# Altium schematic sheet 005_Power.SchDoc(005_Power)
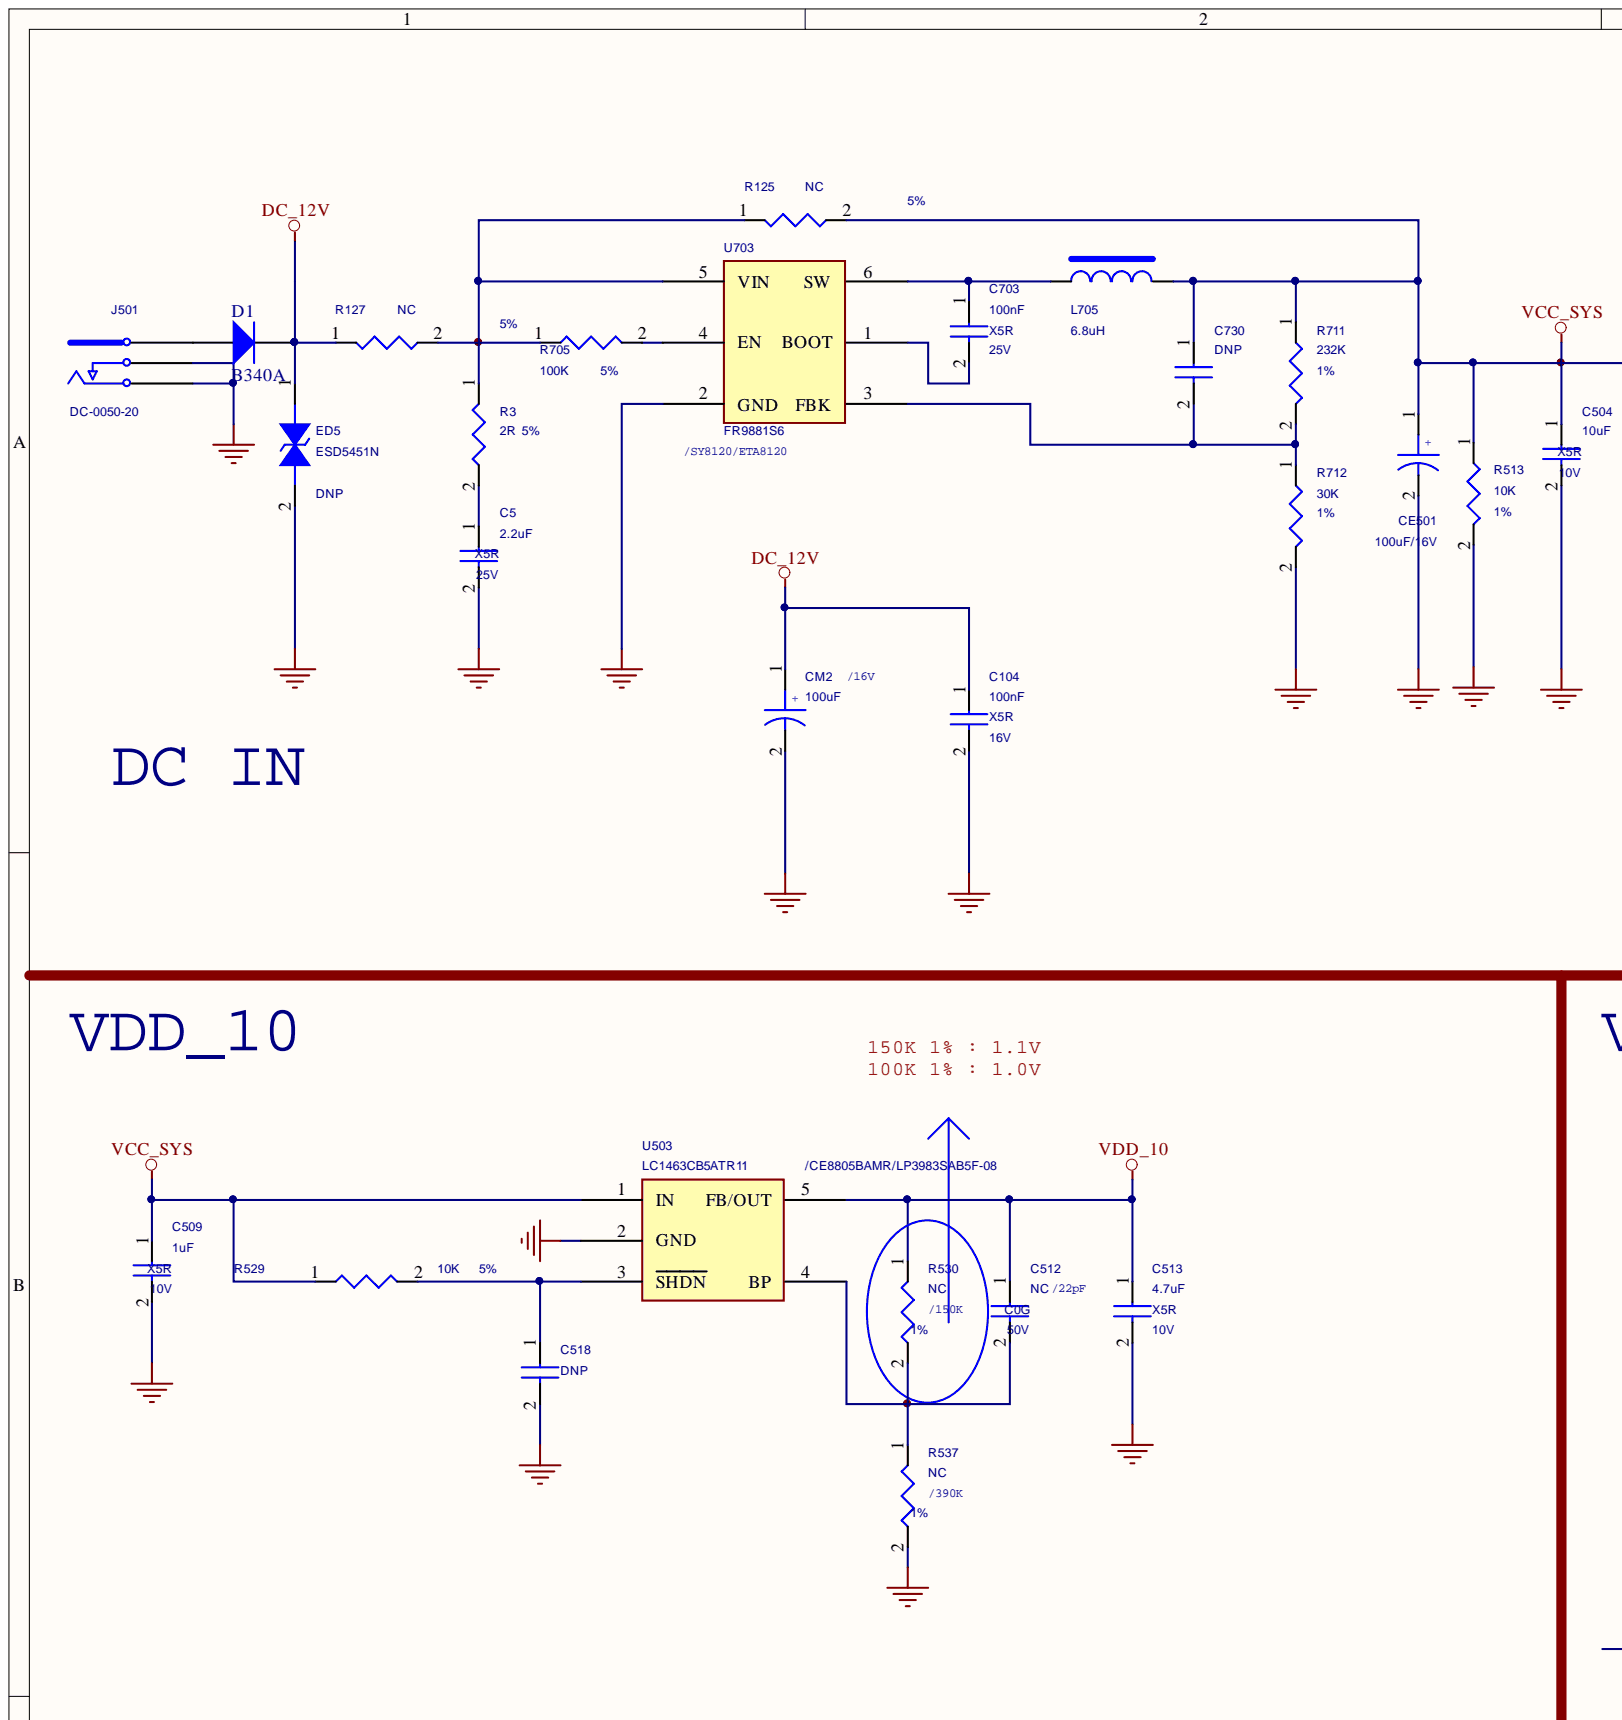
[diagram p0: 005_Power.SchDoc, top left]
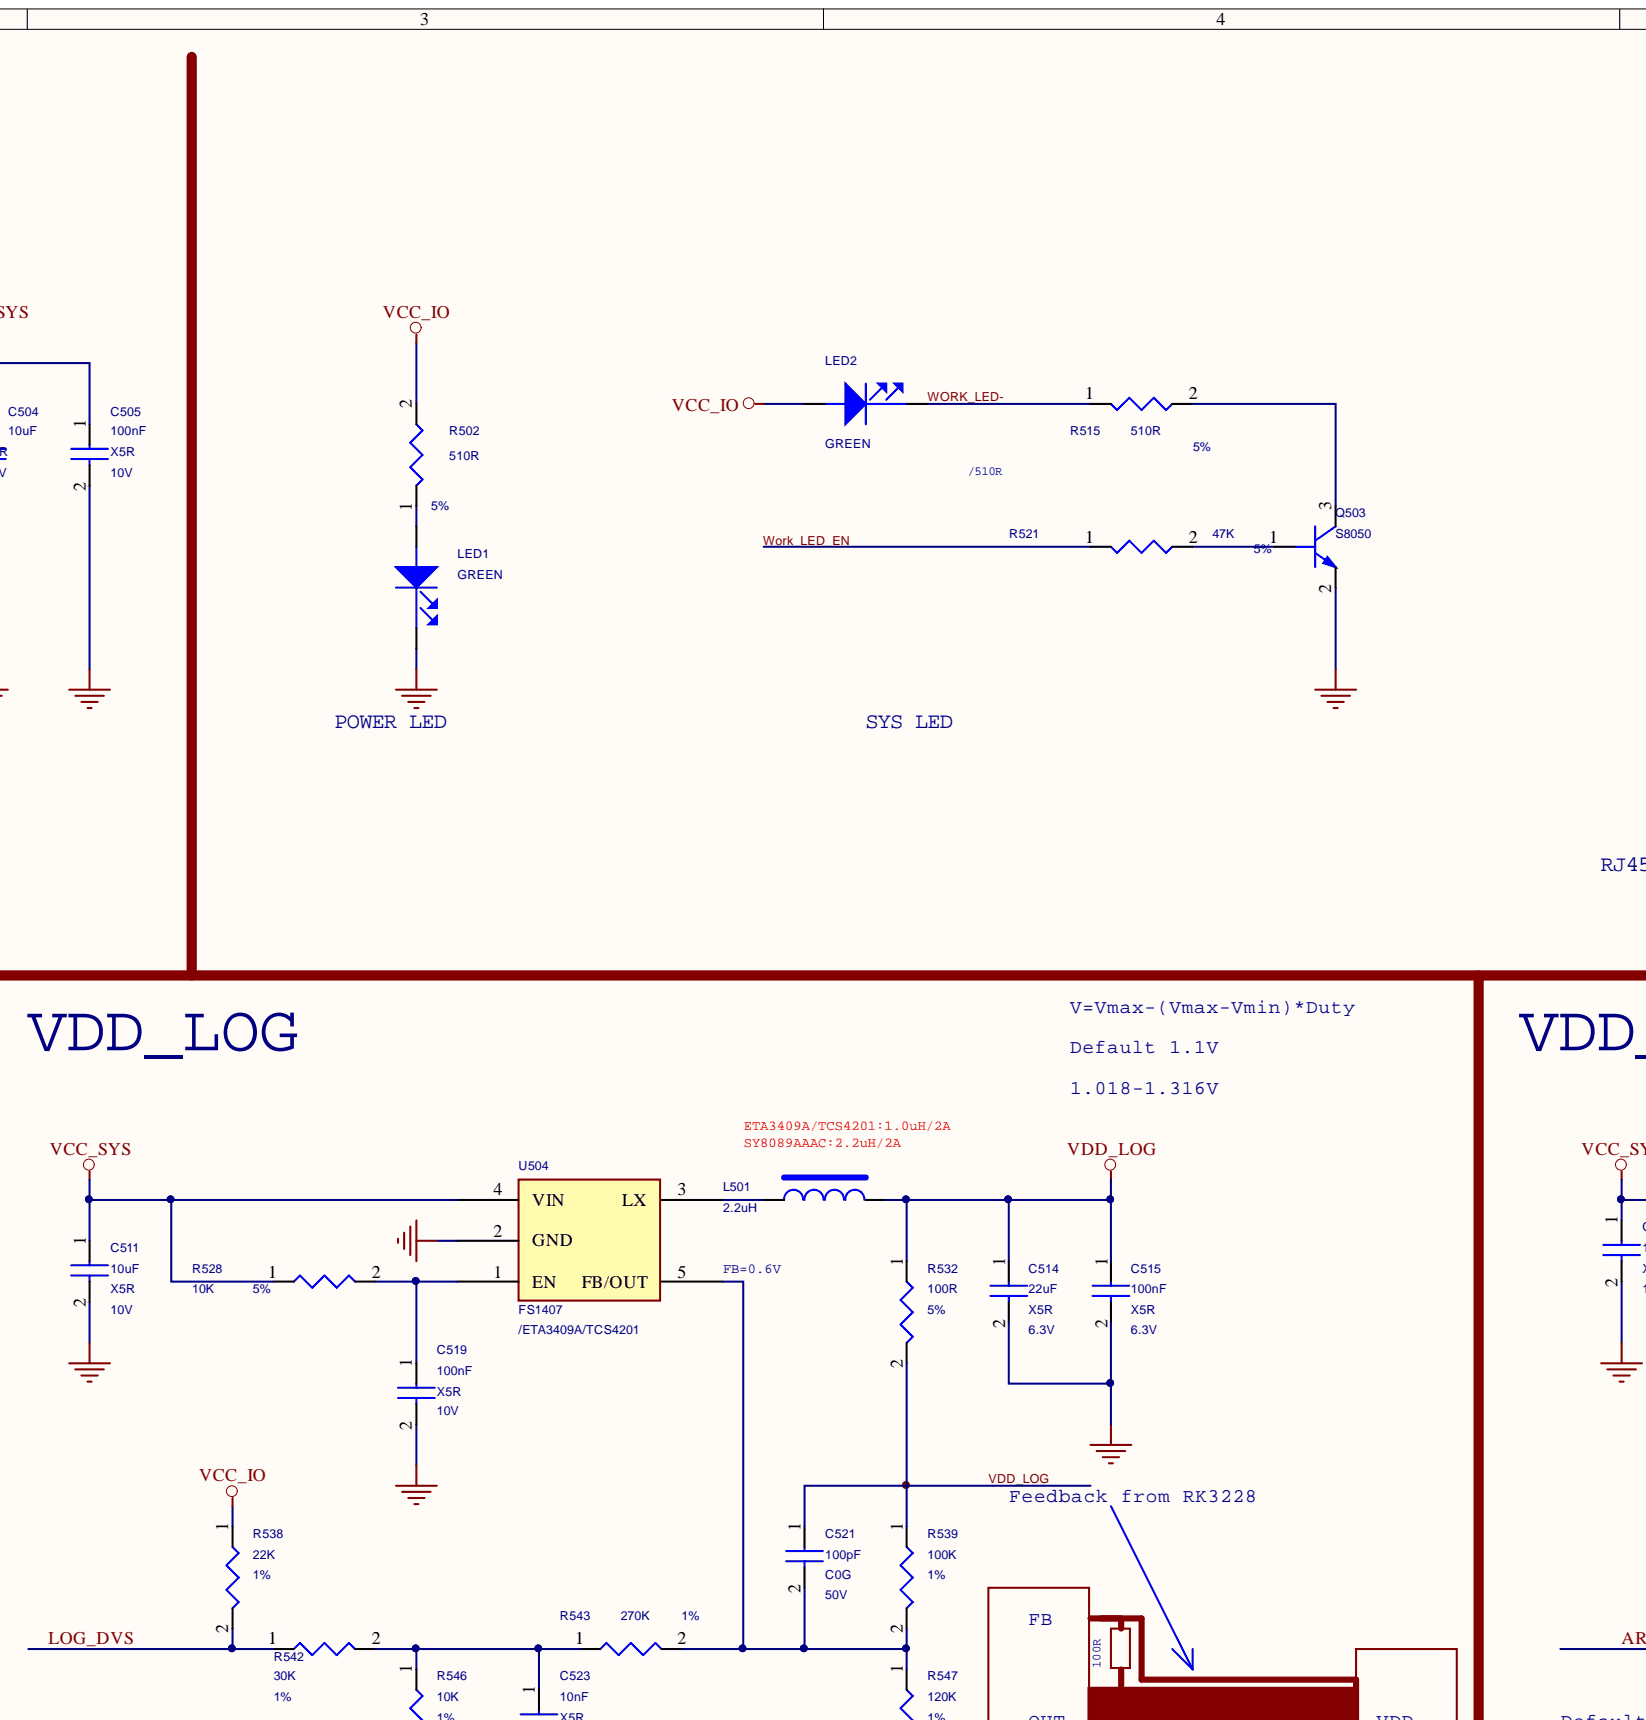
[diagram p1: 005_Power.SchDoc, top centre]
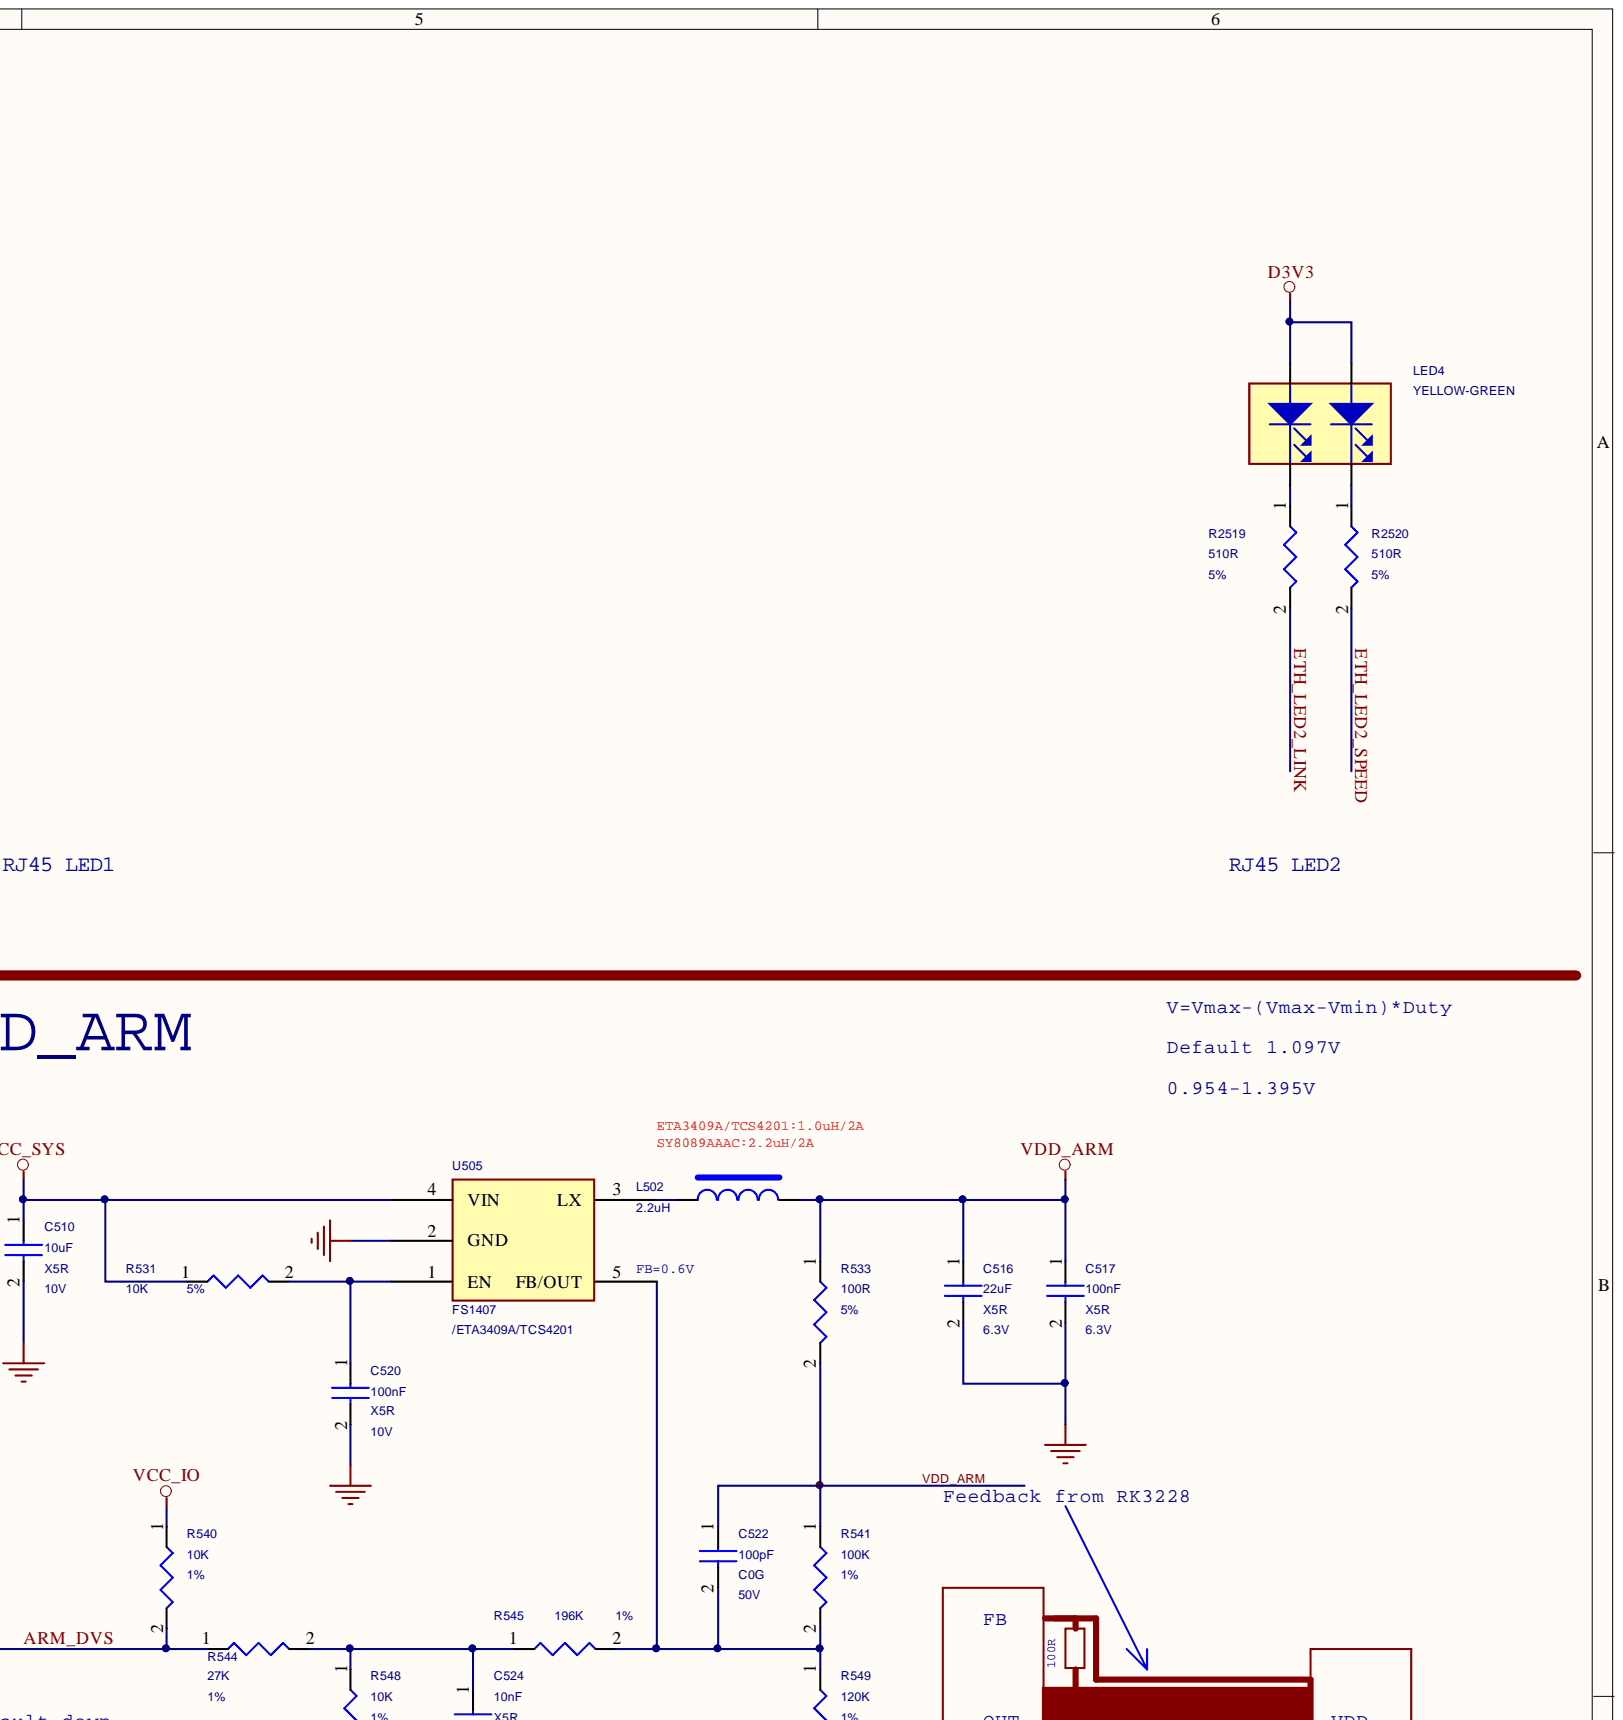
[diagram p2: 005_Power.SchDoc, top right]
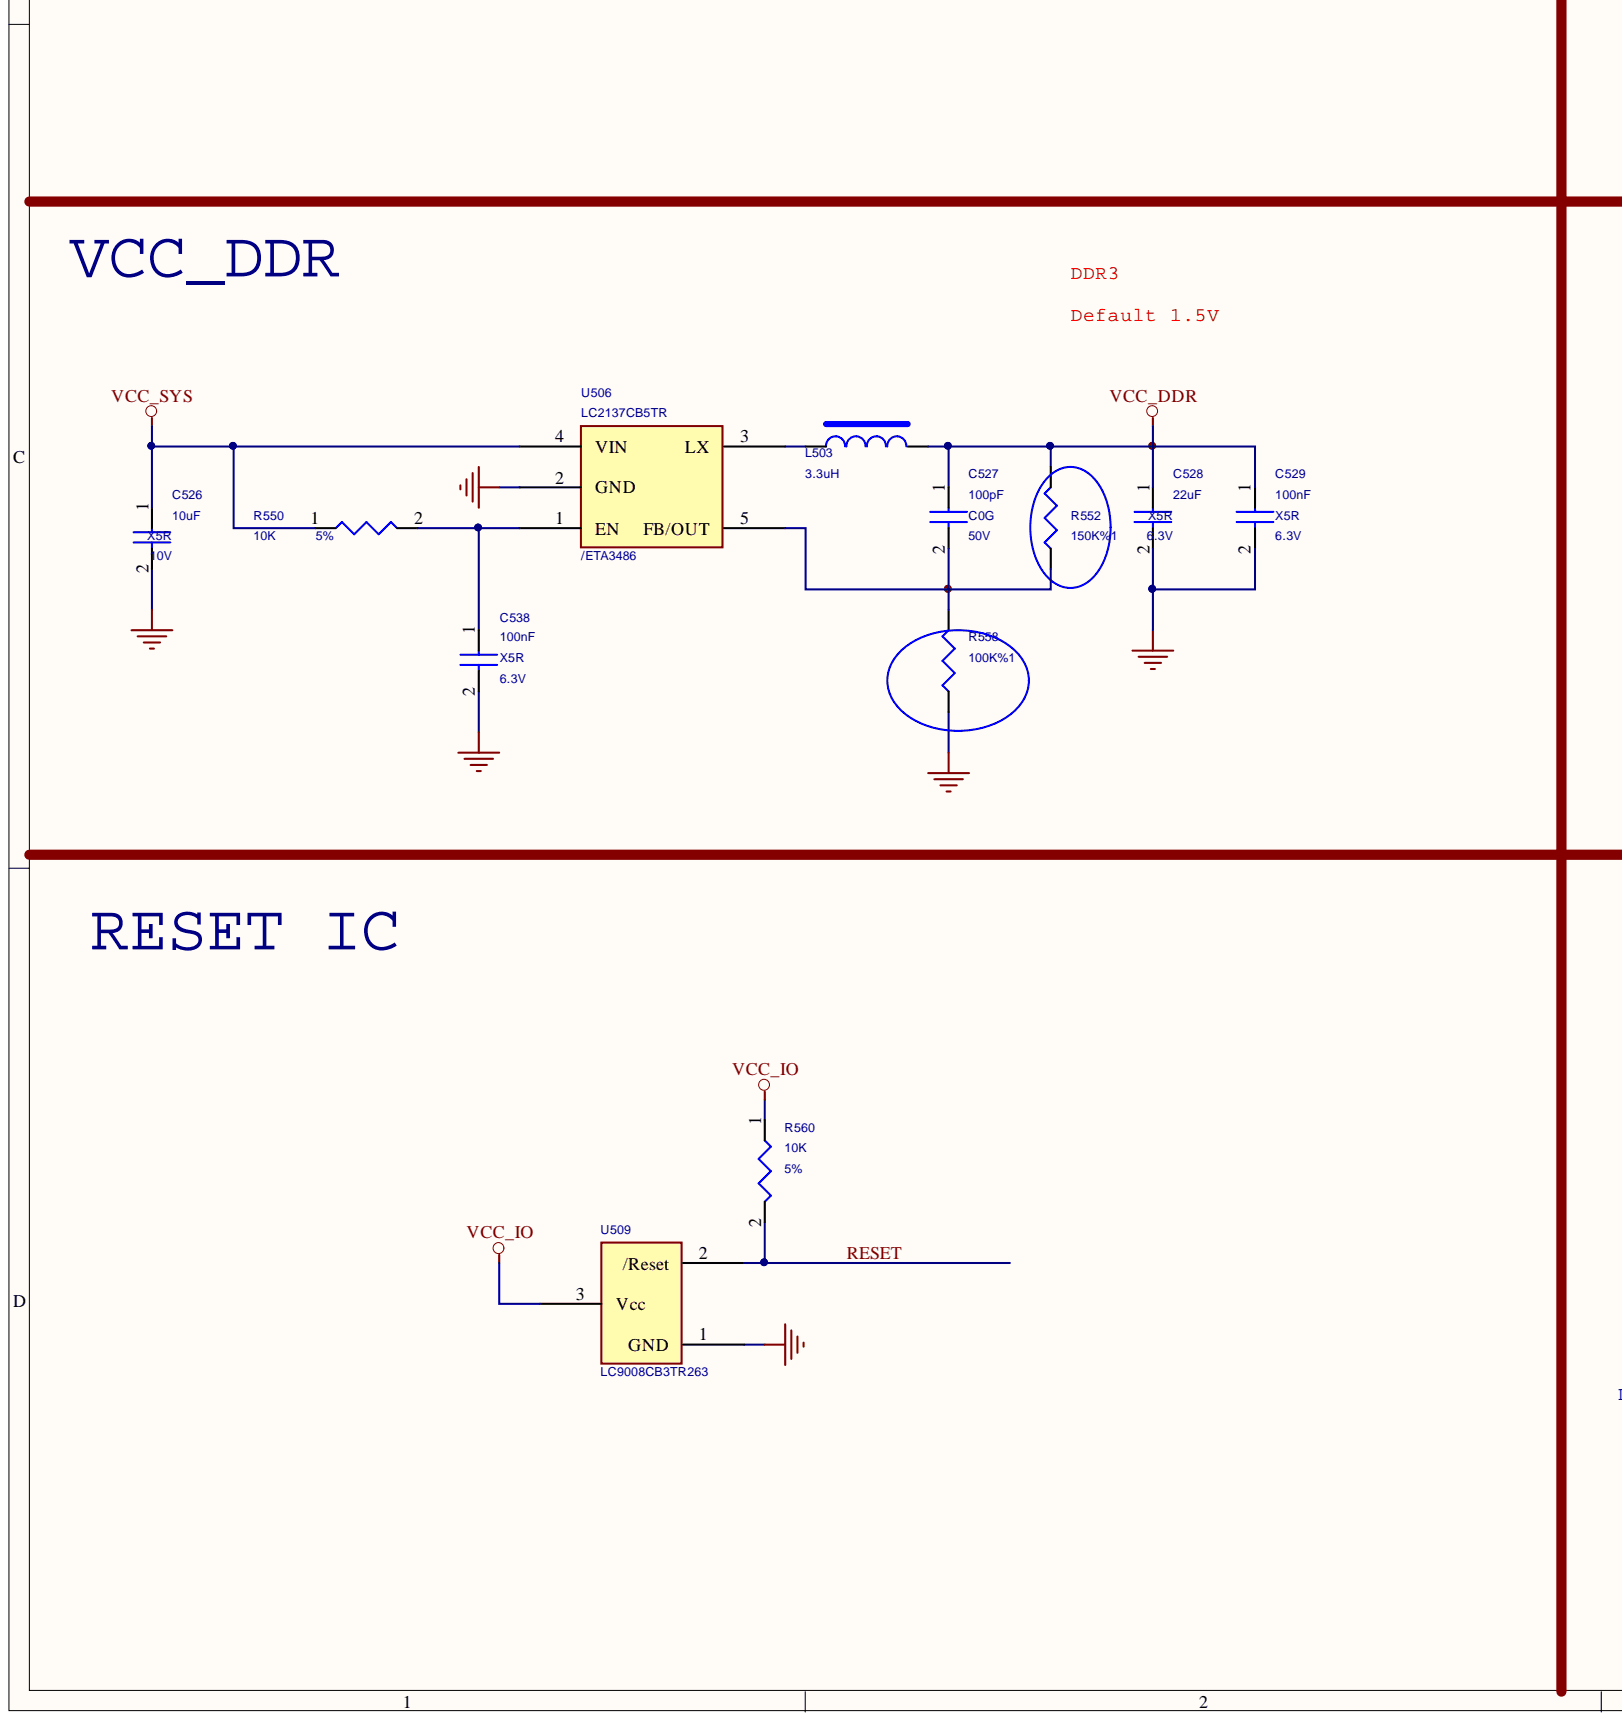
[diagram p3: 005_Power.SchDoc, bottom left]
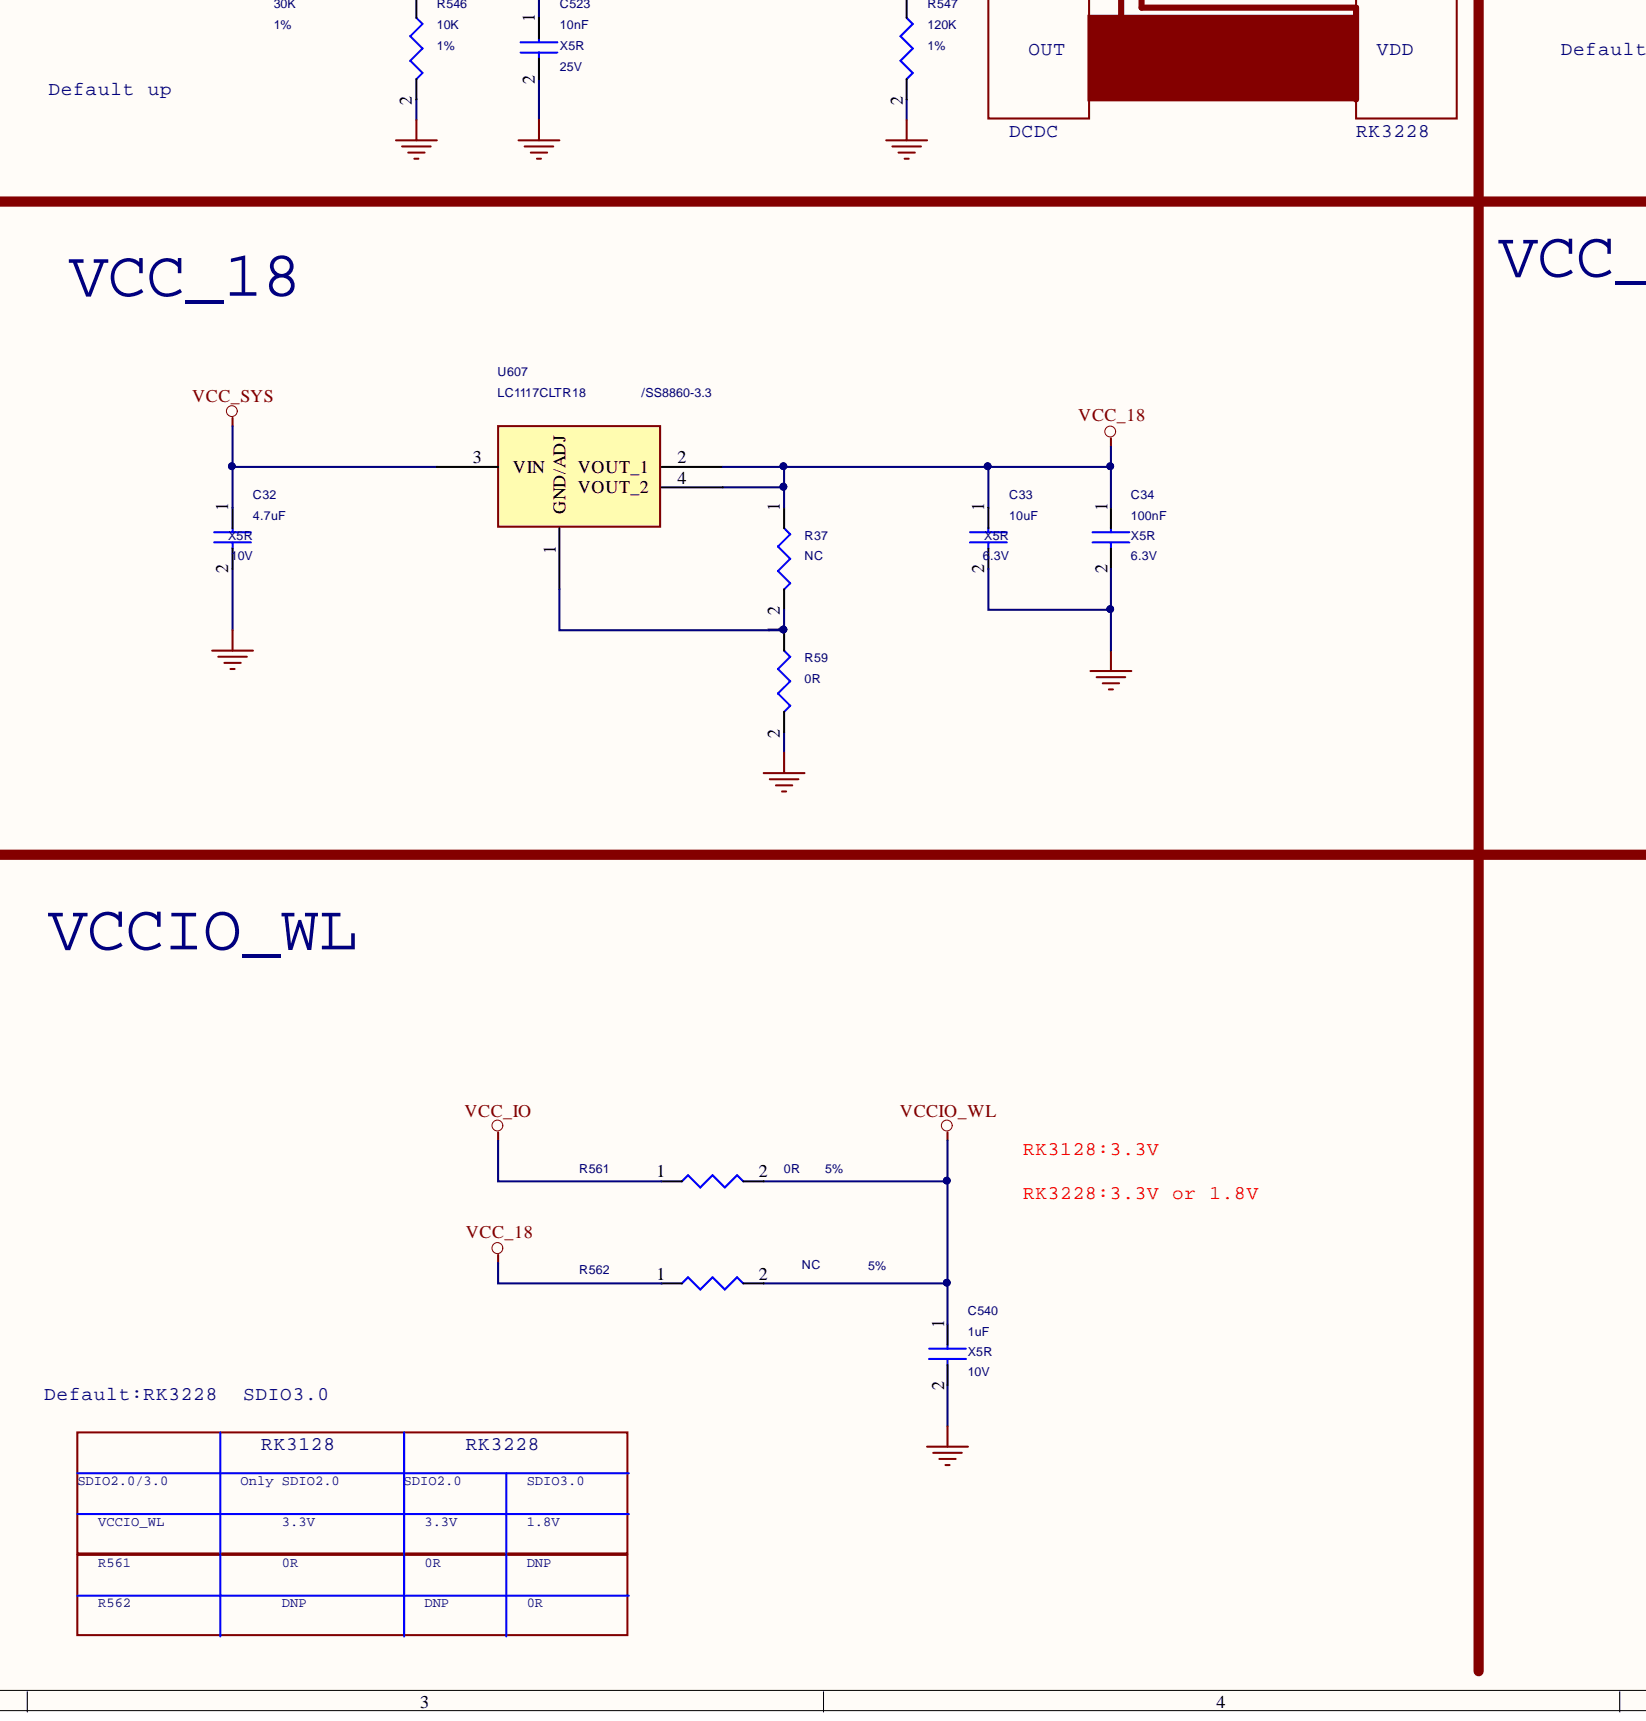
[diagram p4: 005_Power.SchDoc, bottom centre]
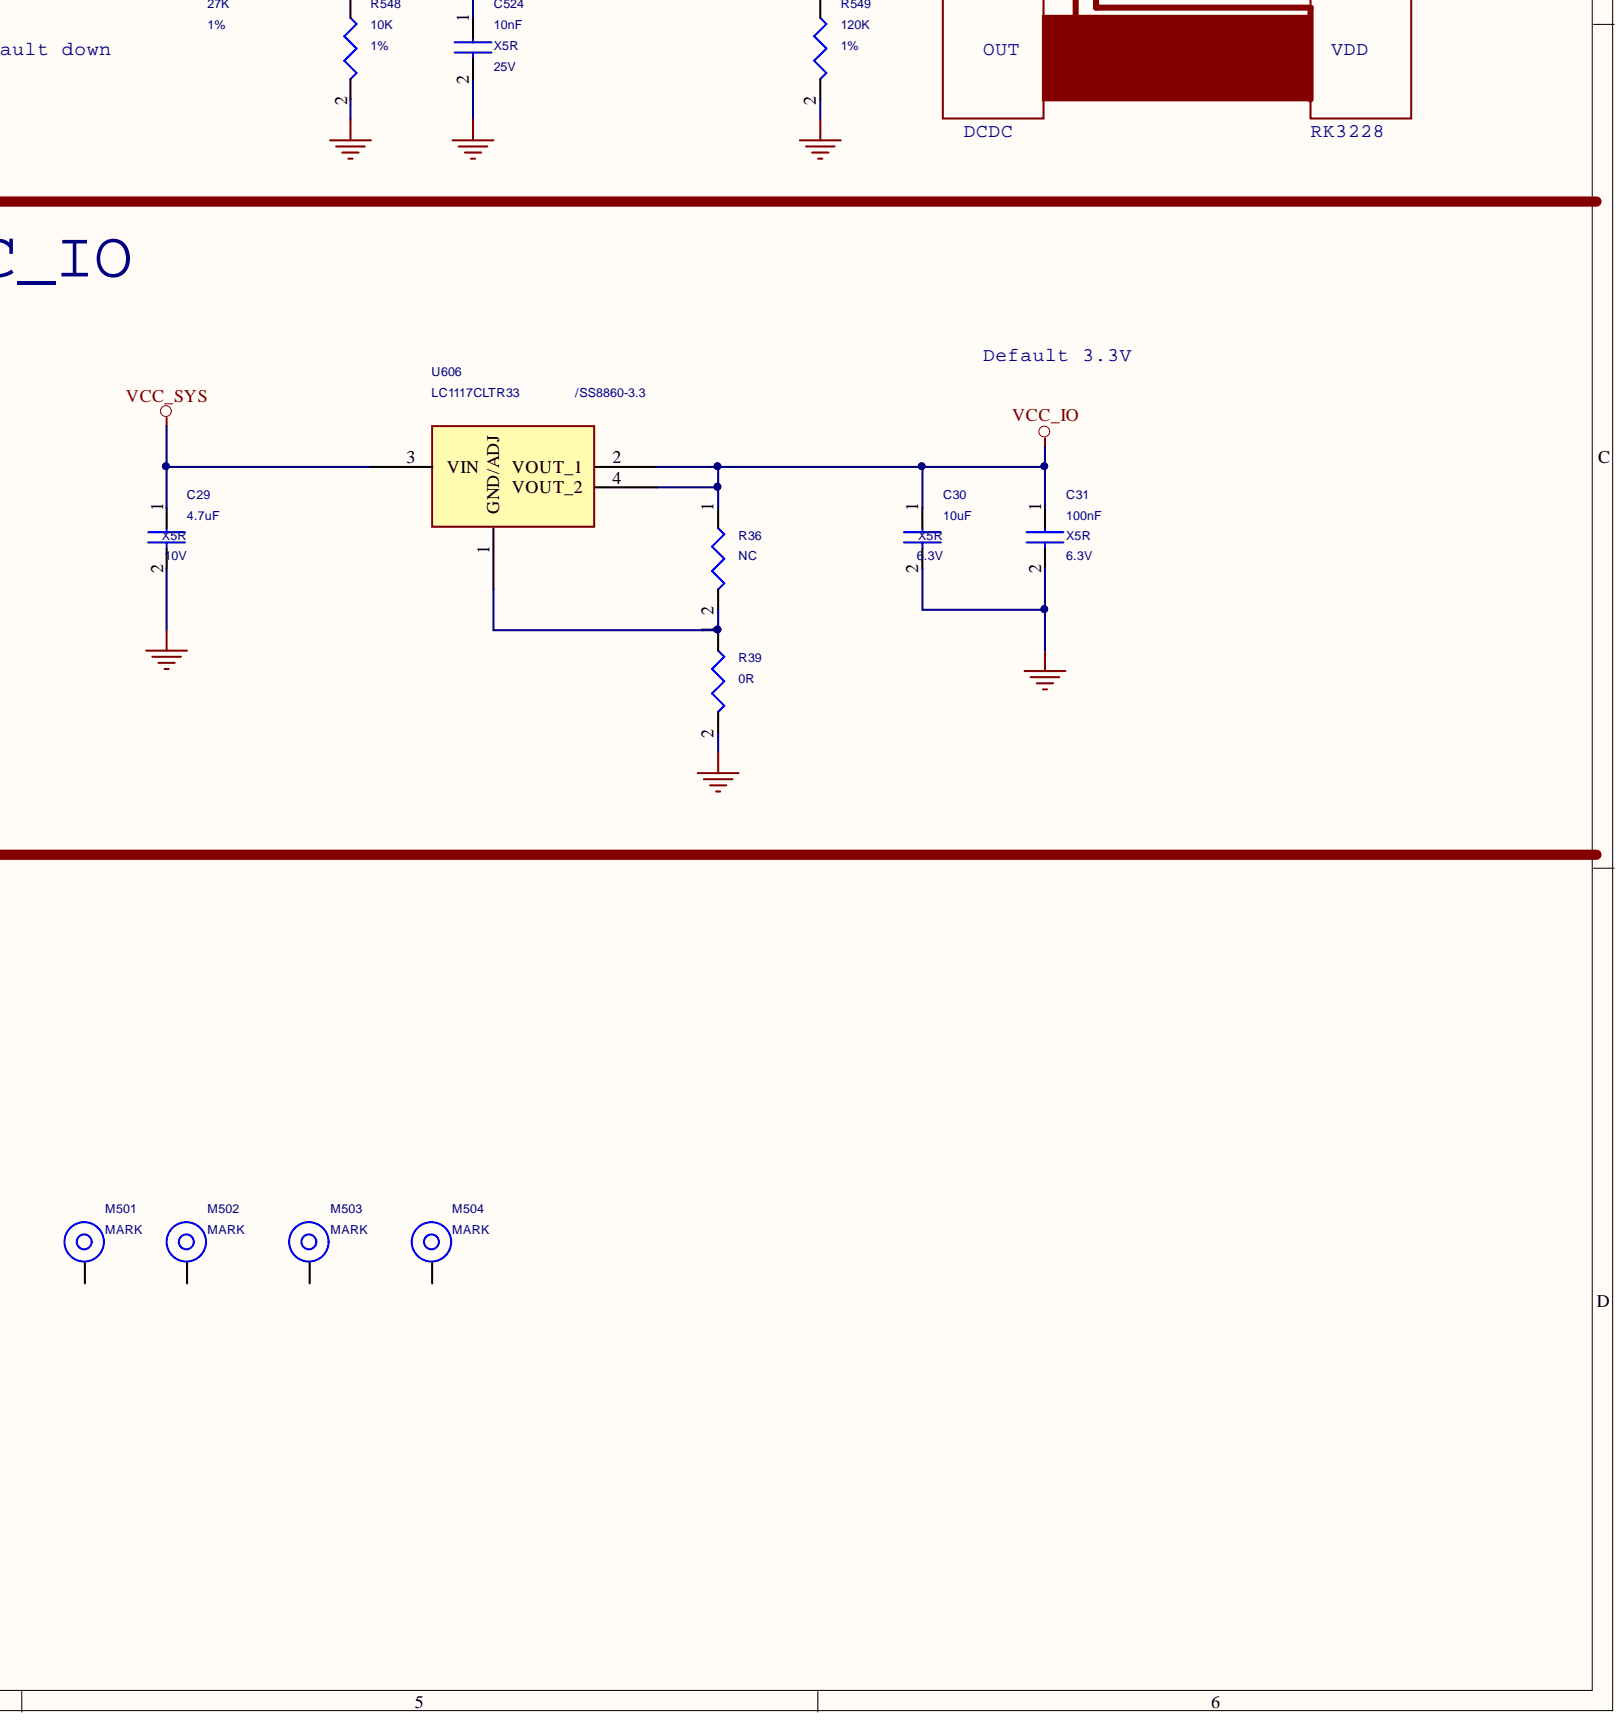
[diagram p5: 005_Power.SchDoc, bottom right]

## Parts
C5  2.2uF  Voltage: 25V  Dielectric: X5R  [C0603]
C29  4.7uF  Voltage: 10V  Dielectric: X5R  [C0603]
C30  10uF  Voltage: 6.3V  Dielectric: X5R  [C0603]
C31  100nF  Voltage: 6.3V  Dielectric: X5R  [C0402]
C32  4.7uF  Voltage: 10V  Dielectric: X5R  [C0603]
C33  10uF  Voltage: 6.3V  Dielectric: X5R  [C0603]
C34  100nF  Voltage: 6.3V  Dielectric: X5R  [C0402]
C104  100nF  Voltage: 16V  Dielectric: X5R  [C0402]
C504  10uF  Voltage: 10V  Dielectric: X5R  [C0603]
C505  100nF  Voltage: 10V  Dielectric: X5R  [C0402]
C509  1uF  Voltage: 10V  Dielectric: X5R  [C0402]
C510  10uF  Voltage: 10V  Dielectric: X5R  [C0603]
C511  10uF  Voltage: 10V  Dielectric: X5R  [C0603]
C512  NC  Voltage: 50V  Dielectric: C0G  [C0402]
C513  4.7uF  Voltage: 10V  Dielectric: X5R  [C0402]
C514  22uF  Voltage: 6.3V  Dielectric: X5R  [C0603]
C515  100nF  Voltage: 6.3V  Dielectric: X5R  [C0402]
C516  22uF  Voltage: 6.3V  Dielectric: X5R  [C0603]
C517  100nF  Voltage: 6.3V  Dielectric: X5R  [C0402]
C518  DNP  [C0402]
C519  100nF  Voltage: 10V  Dielectric: X5R  [C0402]
C520  100nF  Voltage: 10V  Dielectric: X5R  [C0402]
C521  100pF  Voltage: 50V  Dielectric: C0G  [C0402]
C522  100pF  Voltage: 50V  Dielectric: C0G  [C0402]
C523  10nF  Voltage: 25V  Dielectric: X5R  [C0402]
C524  10nF  Voltage: 25V  Dielectric: X5R  [C0402]
C526  10uF  Voltage: 10V  Dielectric: X5R  [C0603]
C527  100pF  Voltage: 50V  Dielectric: C0G  [C0402]
C528  22uF  Voltage: 6.3V  Dielectric: X5R  [C0603]
C529  100nF  Voltage: 6.3V  Dielectric: X5R  [C0402]
C538  100nF  Voltage: 6.3V  Dielectric: X5R  [C0402]
C540  1uF  Voltage: 10V  Dielectric: X5R  [C0402]
C703  100nF  Voltage: 25V  Dielectric: X5R  [C0402]
C730  DNP  [C0402]
CE501  100uF/16V  [CD5.0/2.5]
CM2  100uF  [MTM-C]
D1  B340A  [DO-214AC/SMA]
ED5  ESD5451N  Option: DNP  [ESD0402]
J501  DC-0050-20  [DC-208]
L501  2.2uH  [IND_404026]
L502  2.2uH  [IND_404026]
L503  3.3uH  [IND_303015]
L705  6.8uH  [IND_606028]
LED1  2ND: GREEN  [LED254_1P]  (hidden comment: LED_R)
LED2  2ND: GREEN  [LED254_1P]  (hidden comment: LED_R)
LED4  2ND: YELLOW-GREEN  [LED254_2P]  (hidden comment: LED_R)
M501  MARK  [MARK]
M502  MARK  [MARK]
M503  MARK  [MARK]
M504  MARK  [MARK]
Q503  S8050  [SOT_23]
R3  2R  Tolerance: 5%  [R0603]
R36  NC  [R0402]
R37  NC  [R0402]
R39  0R  [R0402]
R59  0R  [R0402]
R125  NC  Tolerance: 5%  [R0402]
R127  NC  [R1210]
R502  510R  Tolerance: 5%  [R0402]
R513  10K  Tolerance: 1%  [R0402]
R515  510R  Tolerance: 5%  [R0402]
R521  47K  [R0402]
R528  10K  Tolerance: 5%  [R0402]
R529  10K  Tolerance: 5%  [R0402]
R530  NC  Tolerance: 1%  [R0402]
R531  10K  Tolerance: 5%  [R0402]
R532  100R  Tolerance: 5%  [R0402]
R533  100R  Tolerance: 5%  [R0402]
R537  NC  Tolerance: 1%  [R0402]
R538  22K  Tolerance: 1%  [R0402]
R539  100K  Tolerance: 1%  [R0402]
R540  10K  Tolerance: 1%  [R0402]
R541  100K  Tolerance: 1%  [R0402]
R542  30K  Tolerance: 1%  [R0402]
R543  270K  Tolerance: 1%  [R0402]
R544  27K  Tolerance: 1%  [R0402]
R545  196K  Tolerance: 1%  [R0402]
R546  10K  Tolerance: 1%  [R0402]
R547  120K  Tolerance: 1%  [R0402]
R548  10K  Tolerance: 1%  [R0402]
R549  120K  Tolerance: 1%  [R0402]
R550  10K  Tolerance: 5%  [R0402]
R552  150K%1  [R0402]
R558  100K%1  [R0402]
R560  10K  Tolerance: 5%  [R0402]
R561  0R  Tolerance: 5%  [R0402]
R562  NC  [R0402]
R705  100K  Tolerance: 5%  [R0402]
R711  232K  Tolerance: 1%  [R0402]
R712  30K  Tolerance: 1%  [R0402]
R2519  510R  Tolerance: 5%  [R0402]
R2520  510R  Tolerance: 5%  [R0402]
U503  LC1463CB5ATR11  option: /CE8805BAMR/LP3983SAB5F-08  [SOT_23_5]
U504  FS1407  option: /ETA3409A/TCS4201  [SOT_23_5]
U505  FS1407  option: /ETA3409A/TCS4201  [SOT_23_5]
U506  LC2137CB5TR  option: /ETA3486  [SOT_23_5]
U509  LC9008CB3TR263  [SOT_23]
U606  LC1117CLTR33  option: /SS8860-3.3  [SOT-223]
U607  LC1117CLTR18  option: /SS8860-3.3  [SOT-223]
U703  FR9881S6  [SOT-23-6]

## Nets
D3V3: LED4.1 LED4.3
DC_12V: C104.1 CM2.1 D1.2 ED5.1 R127.1
GND: C5.2 C29.2 C30.2 C31.2 C32.2 C33.2 C34.2 C104.2 C504.2 C505.2 C509.2 C510.2 C511.2 C513.2 C514.2 C515.2 C516.2 C517.2 C518.2 C519.2 C520.2 C523.2 C524.2 C526.2 C528.2 C529.2 C538.2 C540.2 CE501.2 CM2.2 ED5.2 J501.2 J501.3 LED1.2 Q503.2 R39.2 R59.2 R513.2 R537.2 R546.2 R547.2 R548.2 R549.2 R558.1 R712.2 U503.2(GND) U504.2(GND) U505.2(GND) U506.2(GND) U509.1 U703.2(GND)
VCC_18: C33.1 C34.1 R37.1 R562.1 U607.2(VOUT_1) U607.4(VOUT_2)
VCC_DDR: C527.1 C528.1 C529.1 L503.2 R552.2
VCC_IO: C30.1 C31.1 LED2.1 R36.1 R502.2 R538.1 R540.1 R560.1 R561.1 U509.3 U606.2(VOUT_1) U606.4(VOUT_2)
VCC_SYS: C29.1 C32.1 C504.1 C505.1 C509.1 C510.1 C511.1 C526.1 C730.1 CE501.1 L705.2 R125.2 R513.1 R528.1 R529.1 R531.1 R550.1 R711.1 U503.1(IN) U504.4(VIN) U505.4(VIN) U506.4(VIN) U606.3(VIN) U607.3(VIN)
VCCIO_WL: C540.1 R561.2 R562.2
VDD_10: C512.1 C513.1 R530.1 U503.5(FB/OUT)
ARM_DVS: R540.2 R544.1
ETH_LED2_LINK: R2519.2
ETH_LED2_SPEED: R2520.2
LOG_DVS: R538.2 R542.1
RESET: R560.2 U509.2
VDD_ARM: C516.1 C517.1 C522.1 L502.2 R533.1 R533.2 R541.1
VDD_LOG: C514.1 C515.1 C521.1 L501.2 R532.1 R532.2 R539.1
WORK_LED-: LED2.2 R515.1
Work_LED_EN: R521.1
NetC5_1: C5.1 R3.2
NetC512_2: C512.2 R530.2 R537.1 U503.4(BP)
NetC518_1: C518.1 R529.2 U503.3(SHDN)
NetC519_1: C519.1 R528.2 U504.1(EN)
NetC520_1: C520.1 R531.2 U505.1(EN)
NetC521_2: C521.2 R539.2 R543.2 R547.1 U504.5(FB/OUT)
NetC522_2: C522.2 R541.2 R545.2 R549.1 U505.5(FB/OUT)
NetC523_1: C523.1 R542.2 R543.1 R546.1
NetC524_1: C524.1 R544.2 R545.1 R548.1
NetC527_2: C527.2 R552.1 R558.2 U506.5(FB/OUT)
NetC538_1: C538.1 R550.2 U506.1(EN)
NetC703_1: C703.1 L705.1 U703.6(SW)
NetC703_2: C703.2 U703.1(BOOT)
NetC730_2: C730.2 R711.2 R712.1 U703.3(FBK)
NetD1_1: D1.1 J501.1
NetL501_1: L501.1 U504.3(LX)
NetL502_1: L502.1 U505.3(LX)
NetL503_1: L503.1 U506.3(LX)
NetLED1_1: LED1.1 R502.1
NetLED4_2: LED4.2 R2519.1
NetLED4_4: LED4.4 R2520.1
NetQ503_1: Q503.1 R521.2
NetQ503_3: Q503.3 R515.2
NetR3_1: R3.1 R125.1 R127.2 R705.1 U703.5(VIN)
NetR36_2: R36.2 R39.1 U606.1(GND/ADJ)
NetR37_2: R37.2 R59.1 U607.1(GND/ADJ)
NetR705_2: R705.2 U703.4(EN)

Not connected: M501 1; M502 1; M503 1; M504 1
